# Altium schematic sheet Sheet5.SchDoc(Sheet5)
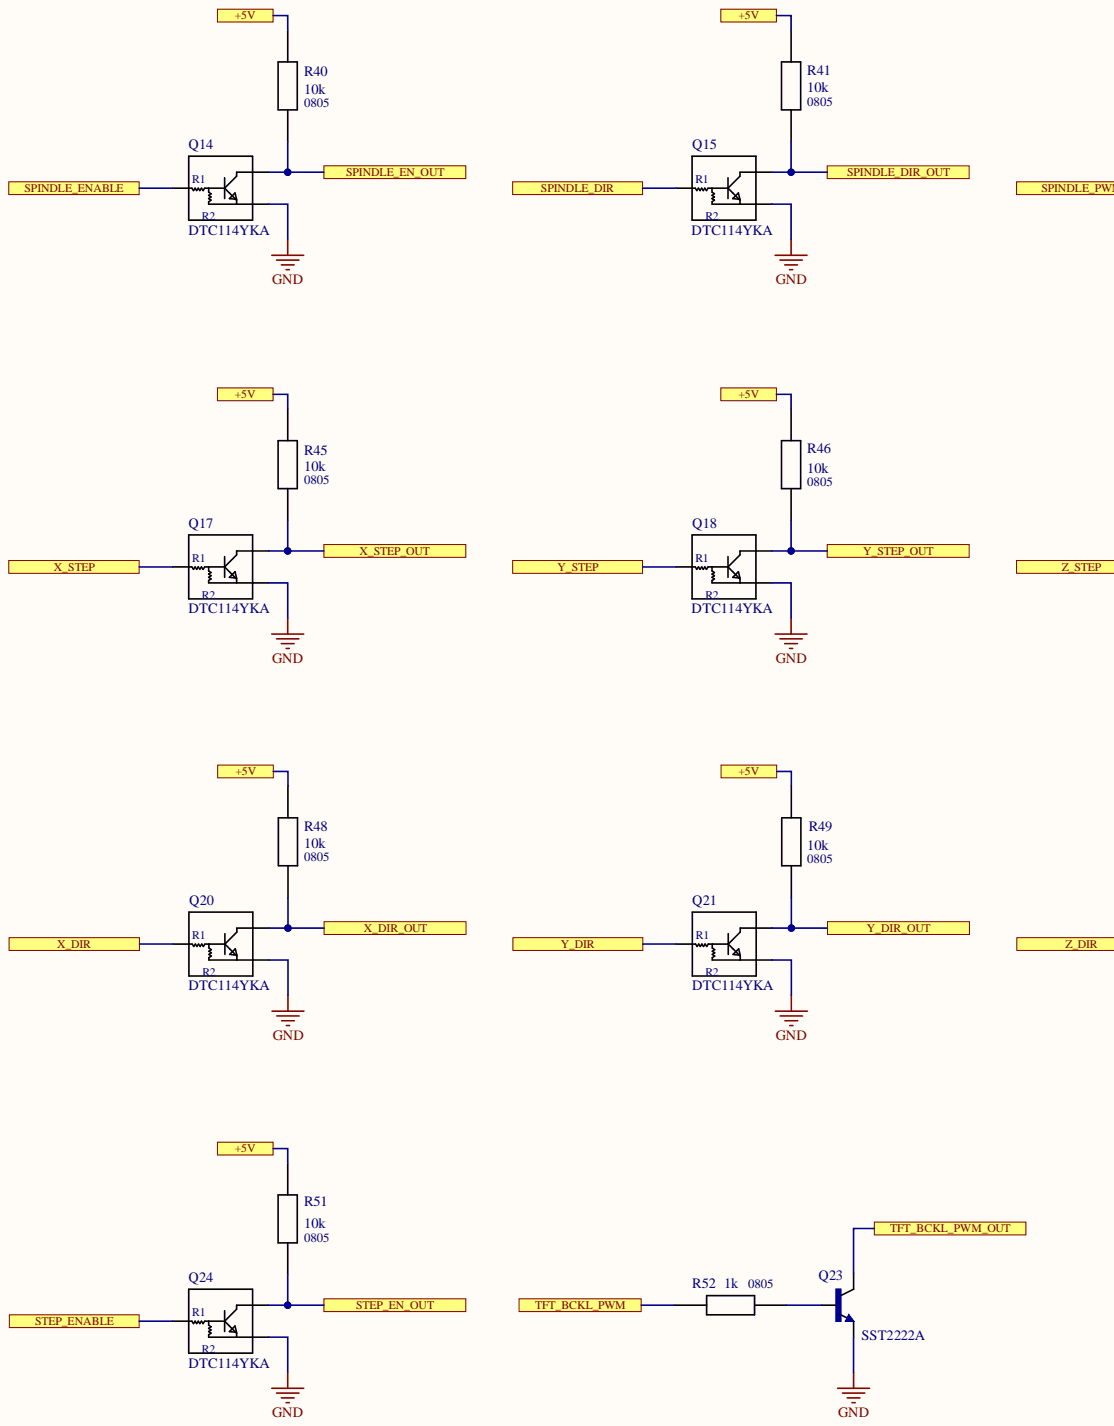
[diagram p0: Sheet5.SchDoc, left]
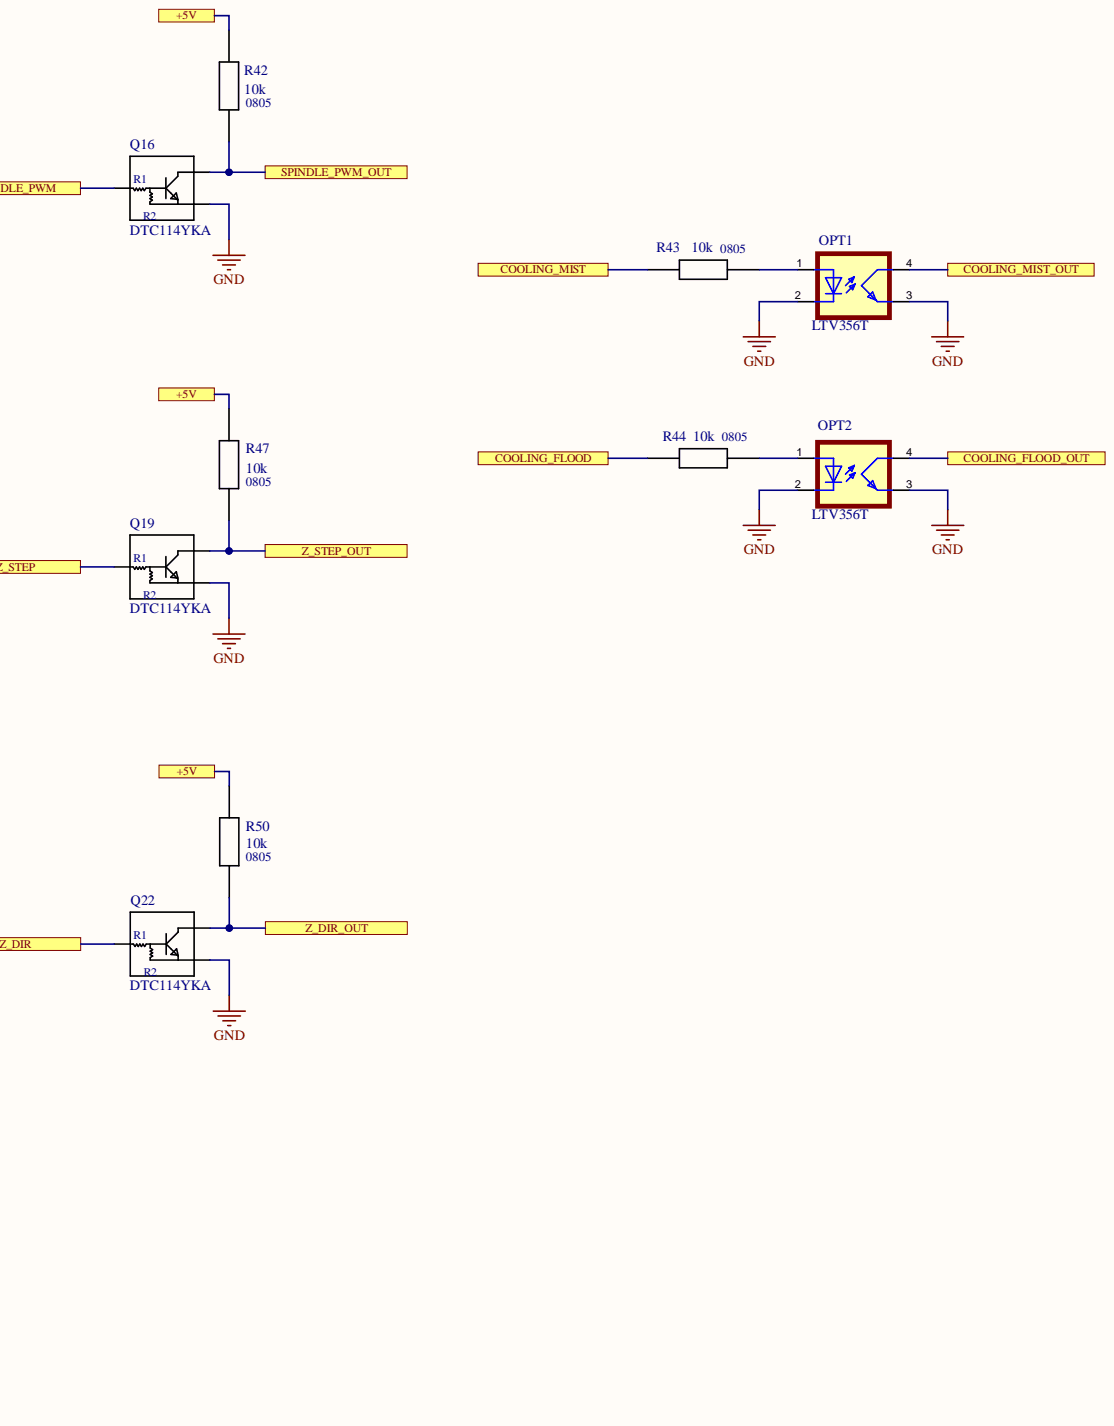
[diagram p1: Sheet5.SchDoc, right]

## Parts
OPT1  LTV356T  [MINI-FLAT-4]
OPT2  LTV356T  [MINI-FLAT-4]
Q14  DTC114YKA  [SOT-346 (SC59) Black]
Q15  DTC114YKA  [SOT-346 (SC59) Black]
Q16  DTC114YKA  [SOT-346 (SC59) Black]
Q17  DTC114YKA  [SOT-346 (SC59) Black]
Q18  DTC114YKA  [SOT-346 (SC59) Black]
Q19  DTC114YKA  [SOT-346 (SC59) Black]
Q20  DTC114YKA  [SOT-346 (SC59) Black]
Q21  DTC114YKA  [SOT-346 (SC59) Black]
Q22  DTC114YKA  [SOT-346 (SC59) Black]
Q23  SST2222A  [SOT-23-3]
Q24  DTC114YKA  [SOT-346 (SC59) Black]
R40  10k  Case: 0805  [SMD 0805]
R41  10k  Case: 0805  [SMD 0805]
R42  10k  Case: 0805  [SMD 0805]
R43  10k  Case: 0805  [SMD 0805]
R44  10k  Case: 0805  [SMD 0805]
R45  10k  Case: 0805  [SMD 0805]
R46  10k  Case: 0805  [SMD 0805]
R47  10k  Case: 0805  [SMD 0805]
R48  10k  Case: 0805  [SMD 0805]
R49  10k  Case: 0805  [SMD 0805]
R50  10k  Case: 0805  [SMD 0805]
R51  10k  Case: 0805  [SMD 0805]
R52  1k  Case: 0805  [SMD 0805]

## Ports
+5V, COOLING_FLOOD, COOLING_FLOOD_OUT, COOLING_MIST, COOLING_MIST_OUT, SPINDLE_DIR, SPINDLE_DIR_OUT, SPINDLE_EN_OUT, SPINDLE_ENABLE, SPINDLE_PWM, SPINDLE_PWM_OUT, STEP_EN_OUT, STEP_ENABLE, TFT_BCKL_PWM, TFT_BCKL_PWM_OUT, X_DIR, X_DIR_OUT, X_STEP, X_STEP_OUT, Y_DIR, Y_DIR_OUT, Y_STEP, Y_STEP_OUT, Z_DIR, Z_DIR_OUT, Z_STEP, Z_STEP_OUT

## Nets
GND: OPT1.2 OPT1.3 OPT2.2 OPT2.3 Q14.2 Q15.2 Q16.2 Q17.2 Q18.2 Q19.2 Q20.2 Q21.2 Q22.2 Q23.2 Q24.2
+5V: R40.1 R41.1 R42.1 R45.1 R46.1 R47.1 R48.1 R49.1 R50.1 R51.1 ; port +5V
COOLING_FLOOD: R44.1 ; port COOLING_FLOOD
COOLING_FLOOD_OUT: OPT2.4 ; port COOLING_FLOOD_OUT
COOLING_MIST: R43.1 ; port COOLING_MIST
COOLING_MIST_OUT: OPT1.4 ; port COOLING_MIST_OUT
SPINDLE_DIR: Q15.1 ; port SPINDLE_DIR
SPINDLE_DIR_OUT: Q15.3 R41.2 ; port SPINDLE_DIR_OUT
SPINDLE_EN_OUT: Q14.3 R40.2 ; port SPINDLE_EN_OUT
SPINDLE_ENABLE: Q14.1 ; port SPINDLE_ENABLE
SPINDLE_PWM: Q16.1 ; port SPINDLE_PWM
SPINDLE_PWM_OUT: Q16.3 R42.2 ; port SPINDLE_PWM_OUT
STEP_EN_OUT: Q24.3 R51.2 ; port STEP_EN_OUT
STEP_ENABLE: Q24.1 ; port STEP_ENABLE
TFT_BCKL_PWM: R52.1 ; port TFT_BCKL_PWM
TFT_BCKL_PWM_OUT: Q23.3 ; port TFT_BCKL_PWM_OUT
X_DIR: Q20.1 ; port X_DIR
X_DIR_OUT: Q20.3 R48.2 ; port X_DIR_OUT
X_STEP: Q17.1 ; port X_STEP
X_STEP_OUT: Q17.3 R45.2 ; port X_STEP_OUT
Y_DIR: Q21.1 ; port Y_DIR
Y_DIR_OUT: Q21.3 R49.2 ; port Y_DIR_OUT
Y_STEP: Q18.1 ; port Y_STEP
Y_STEP_OUT: Q18.3 R46.2 ; port Y_STEP_OUT
Z_DIR: Q22.1 ; port Z_DIR
Z_DIR_OUT: Q22.3 R50.2 ; port Z_DIR_OUT
Z_STEP: Q19.1 ; port Z_STEP
Z_STEP_OUT: Q19.3 R47.2 ; port Z_STEP_OUT
NetOPT1_1: OPT1.1 R43.2
NetOPT2_1: OPT2.1 R44.2
NetQ23_1: Q23.1 R52.2

## Library data of the parts
OPT1 OPT2 = LTV-356T: Optoisolator Transistor Output 3750Vrms 1 Channel 4-SOP; MF: LITEON; Component Kind: Standard; Library Name: CAN_Library.IntLib; Package: SOP-4 Lite-On Inc.; Value: LTV356T
Q14-Q22 Q24 = DTC114YKA: NPN Digital 50V 100mA 200mW  R1=10kOm R2=47kOm; Manufacturer: ROHM Semiconductor; Case: SOT-346 (SC59); Component Kind: Standard; HalpURL: https://store.comet.bg/Catalogue/Product/23715/; Library Name: CAN_Library.IntLib; Value: DTC114YKA
Q23 = SST2222A: Transistor NPN 40V 600mA 300MHz 350mW; Manufacturer: ROHM Semiconductor; Case: SOT-23-3 SST3; Component Kind: Standard; HelpURL: https://store.comet.bg/Catalogue/Product/23715/; Library Name: CAN_Library.IntLib; Value: SST2222A
R40-R52 = Resistor_1: RES; Component Kind: Standard; HelpURL: https://store.comet.bg/Catalogue/RES/Resistors/#eyJpcHAiOiIxMDAiLCJtIjoiIn0%3D; Library Name: CAN_Library.IntLib
  R40-R51: Value: 10k
  R52: Value: 1k
